# Altium schematic sheet Boom Control.SchDoc("Boom Control")
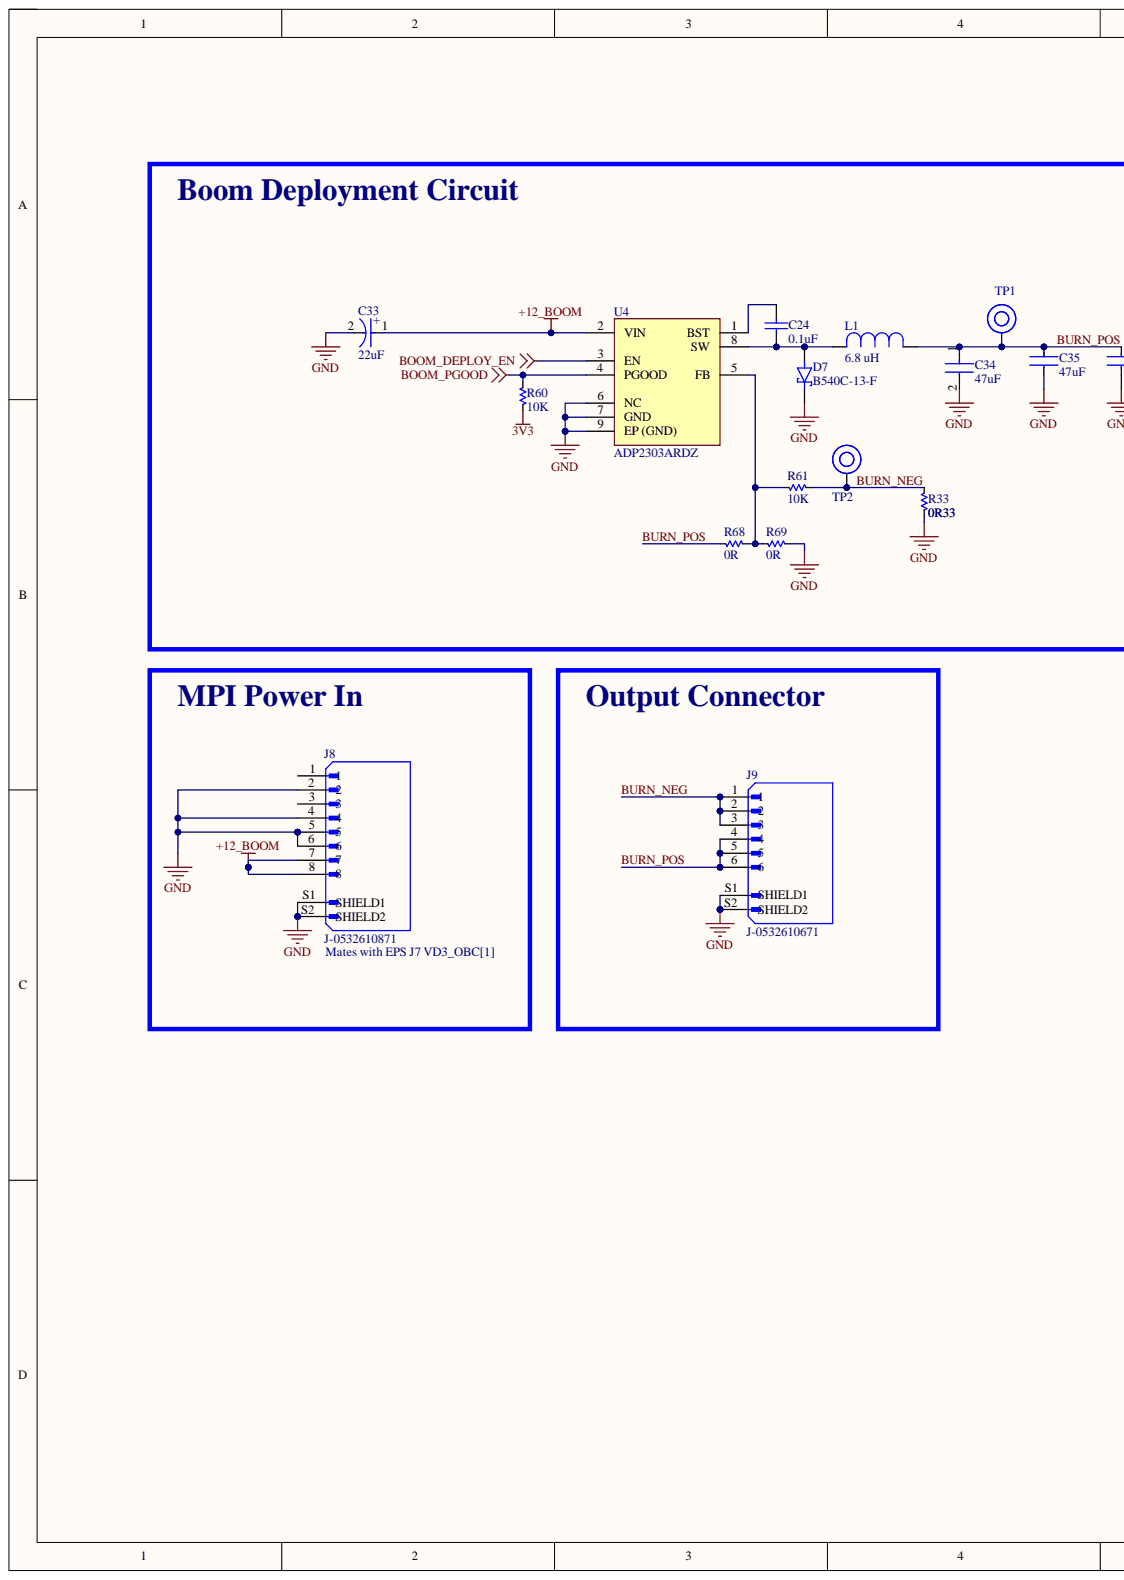
[diagram p0: Boom Control.SchDoc, left]
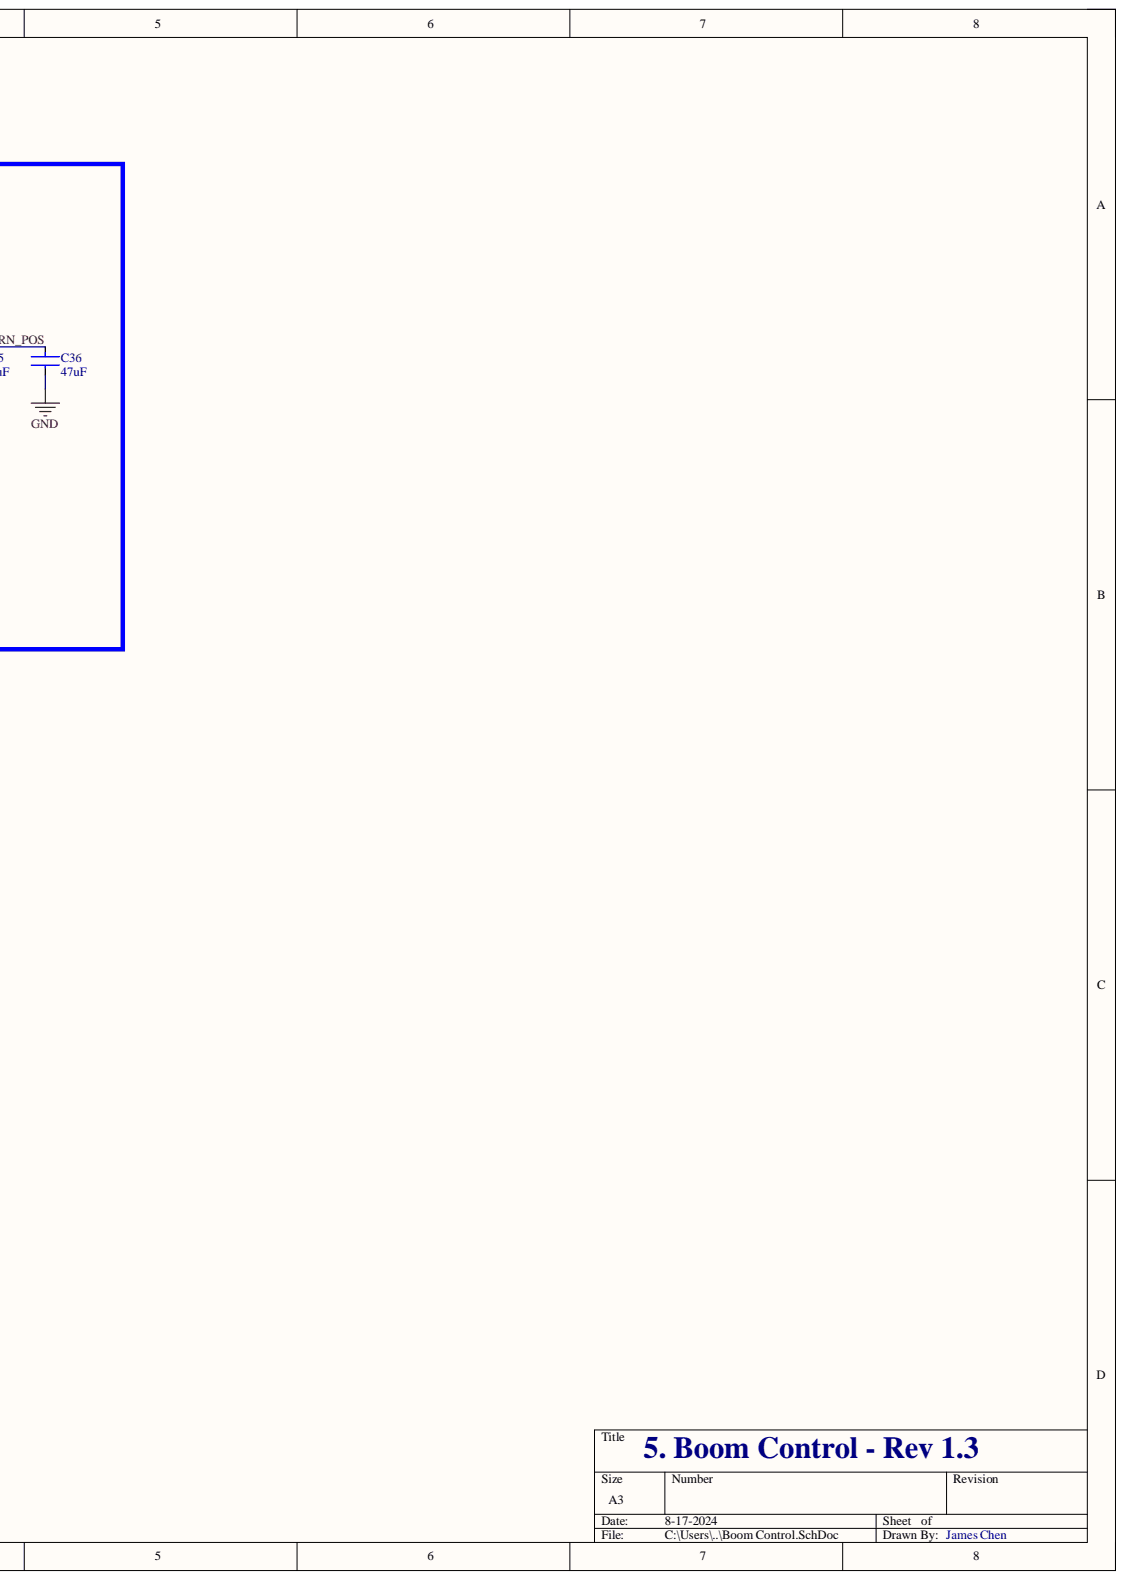
[diagram p1: Boom Control.SchDoc, right]

## Parts
C24  0.1uF  [0603]
C33  22uF  [CAP TANT 1206 (A) TCJ]
C34  47uF  [FP-GRM32E-0_3-0_2-MFG]
C35  47uF  [FP-GRM32E-0_3-0_2-MFG]
C36  47uF  [FP-GRM32E-0_3-0_2-MFG]
D7  B540C-13-F  [D-B540C-13-F]
J8  J-0532610871  [J-532610871]
J9  J-0532610671  [J-0532610671]
L1  6.8 UH  [L-IHLP4040DZER6R8M01]
R33  0R33  [2512]
R60  10K  [0603]
R61  10K  [0603]
R68  0R  [0603]
R69  0R  [0603]
TP1  TP  [TP]  (no BOM)
TP2  TP  [TP]  (no BOM)
U4  ADP2303ARDZ  [U-ADP2303ARDZ]

## Nets
3V3: R60.1
+12_BOOM: C33.1 J8.7 J8.8 U4.2(VIN)
BOOM_DEPLOY_EN: U4.3(EN)
BOOM_PGOOD: R60.2 U4.4(PGOOD)
GND: C33.2 C34.2 C35.2 C36.2 D7.C J8.2 J8.4 J8.5 J8.6 J8.S1(SHIELD1) J8.S2(SHIELD2) J9.S1(SHIELD1) J9.S2(SHIELD2) R33.1 R69.2 U4.6(NC) U4.7(GND) U4.9(EP_(GND))
BURN_NEG: J9.1 J9.2 J9.3 R33.2 R61.2 TP2.1
BURN_POS: C34.1 C35.1 C36.1 J9.4 J9.5 J9.6 L1.1 R68.1 TP1.1
NetC24_1: C24.1 D7.A L1.2 U4.8(SW)
NetC24_2: C24.2 U4.1(BST)
NetR61_1: R61.1 R68.2 R69.1 U4.5(FB)

Not connected: J8 1 3

## Library data of the parts
C24 = CC-100nF-25V-X7R-0603: 25V 100nF X7R ±10% 0603 Multilayer Ceramic Capacitors MLCC - SMD/SMT ROHS; Manufacturer: Murata Electronics; Manufacturer Part #: GCJ188R71E104KA12D; Max Exposed Voltage: 6; Rated Voltage: 25; Component Kind: Standard; ComponentLink1description: Datasheet; ComponentLink1URL: https://ds.yuden.co.jp/TYCOMPAS/ut/detail?pn=TMK105BJ104KV-F%20%20&u=M; ComponentLink2description: Manufacturer Website; ComponentLink2URL: https://www.yuden.co.jp/or/; Distributor: LCSC; Distributor Part #: C354391; Highest Rated Operating Temperature: 125; Lowest Rated Operating Temperature: -55; Part Description: 25V 100nF X7R ±10% 0603 Multilayer Ceramic Capacitors MLCC - SMD/SMT ROHS; Value: 0.1uF; Library Name: OBC Development Board.SchLib
C33 = CMP-04424-000242-1: 22uF 25V  ±10% 1.4Ω 2312  SMD Tantalum Capacitor, TAJC226K025RNJ; Dissipation Factor: 6%; ESR (Equivalent Series Resistance): 1.4R; Leakage Current: 5.5uA; Polarity: Polar; Capacitance: 22uF; Case Code (Imperial): 2312; Case Code (Metric): 6032; Case/Package: 2412; Component Kind: Standard; Construction: Flat; Depth: 3.2mm; Dielectric Material: Tantalum; Features: General Purpose; Height: 2.6mm; Height - Seated (Max): 0.11inch; Lead Free: Lead Free; Length: 6mm; Library Name: Altium Content Vault; Max Operating Temperature: 125°C; Min Operating Temperature: -55°C; Mount: Surface Mount; Number of Pins: 2; Package Quantity: 1; Packaging: Tape and Reel; Radiation Hardening: No; REACH SVHC: No SVHC; RoHS Compliant: Yes; Series: TAJ; Termination: SMD/SMT; Tolerance: 10%; Voltage: 25V; Voltage Rating: 25V; Voltage Rating (DC): 25V; Width: 3.2mm
C34 = CMP-2000-06360-2: CAP CER 47UF 6.3V X5R 1210; Dielectric Material: Ceramic; Insulation Resistance: 10.6MR; Tolerance: 10%; Capacitance: 47uF; Case Code (Imperial): 1210; Case Code (Metric): 3225; Case/Package: 1210; Component Kind: Standard; Construction: Flat; Depth: 2.5mm; Dielectric: X5R; Height: 2.5mm; Lead Free: Lead Free; Length: 3.2mm; Library Name: Altium Content Vault; Max Operating Temperature: 85°C; Min Operating Temperature: -55°C; Mount: Surface Mount; Package Quantity: 1; Packaging: Tape and Reel; Radiation Hardening: No; REACH SVHC: No SVHC; RoHS Compliant: Yes; Series: GRM; Termination: SMD/SMT; Thickness: 0.106inch; Voltage: 6.3V; Voltage Rating: 6.3V; Voltage Rating (DC): 6.3V; Weight: 0.000564oz; Width: 2.5mm
C35 C36 = CC-GRM32ER60J476ME20L: CAP CER 47UF 6.3V X5R 1210; Dielectric Material: Ceramic; Insulation Resistance: 10.6MR; Tolerance: 10%; Capacitance: 47uF; Case Code (Imperial): 1210; Case Code (Metric): 3225; Case/Package: 1210; Component Kind: Standard; Construction: Flat; Depth: 2.5mm; Dielectric: X5R; Height: 2.5mm; Lead Free: Lead Free; Length: 3.2mm; Max Operating Temperature: 85°C; Min Operating Temperature: -55°C; Mount: Surface Mount; Package Quantity: 1; Packaging: Tape and Reel; Radiation Hardening: No; REACH SVHC: No SVHC; RoHS Compliant: Yes; Series: GRM; Termination: SMD/SMT; Thickness: 0.106inch; Voltage: 6.3V; Voltage Rating: 6.3V; Voltage Rating (DC): 6.3V; Weight: 0.000564oz; Width: 2.5mm; Library Name: OBC Development Board.SchLib
D7 = D-B540C-13-F: DIODE SCHOTTKY 40V 5A SMC; Manufacturer: Diodes Incorporated; Component Kind: Standard; Distributor: Digikey; Distributor Part #: B540C-FDICT-ND; Manufacturer Part #: B540C-13-F; Library Name: Burn Wire Dev Board.SchLib
J8 = J-0532610871: CONN HEADER SMD R/A 8POS 1.25MM; Manfacturer: Molex; Component Kind: Standard; Distributor: Digi-Key; Distributor Part #: WM7626CT-ND; Manfacturer Part #: 0532610871; Library Name: OBC Development Board.SchLib
J9 = J-0532610671: CONN HEADER SMD R/A 6POS 1.25MM; Manfacturer: Molex; Component Kind: Standard; Distributor: Digi-Key; Distributor Part #: WM7624CT-ND; Manfacturer Part #: 0532610671; Library Name: Burn Wire Dev Board.SchLib
L1 = L-IHLP4040DZER6R8M01: FIXED IND 6.8UH 8A 23.3 MOHM SMD; Manufacturer: Vishay Dale; Component Kind: Standard; Distributor: Digikey; Distributor Part #: 541-1303-1-ND; Manufacturer Part #: IHLP4040DZER6R8M01; Value: 6.8 uH; Library Name: Burn Wire Dev Board.SchLib
R33 = RT-0R33-F-4W-2512: 330 mOhms ±1% 4W Chip Resistor 2512 (6432 Metric) Automotive AEC-Q200, Current Sense, Moisture Resistant, Pulse Withstanding Metal Foil; Manufacturer: Isabellenhuette USA; Manufacturer Part #: VMS-R330-1.0-U; Component Kind: Standard; Distributer: Digikey; Distributer #: 4423-VMS-R330-1.0-UCT-ND; Part Description: 330 mOhms ±1% 4W Chip Resistor 2512 (6432 Metric) Automotive AEC-Q200, Current Sense, Moisture Resistant, Pulse Withstanding Metal Foil; Supplier 1: Digikey; Supplier Part Number 1: 4423-VMS-R330-1.0-UCT-ND; Value: 0R33; Library Name: OBC Development Board.SchLib
R60 R61 = RT-10K0-F-1/16W-0603: RES 10K OHM 1% 1/8W 0603; Manufacturer: Stackpole Electronics Inc; Manufacturer Part #: RNCP0603FTD10K0; Component Kind: Standard; ComponentLink1description: Datasheet; ComponentLink1URL: https://www.vishay.com/docs/20035/dcrcwe3.pdf; ComponentLink2description: Manufacturer Website; ComponentLink2URL: https://www.vishay.com/; Distributor: Digikey; Distributor Part #: RNCP0603FTD10K0CT-ND; Part Description: RES 10K OHM 1% 1/8W 0603; Value: 10K; Library Name: Burn Wire Dev Board.SchLib
R68 R69 = RT-0R00-F-1/10W-0603: 0 Ohms Jumper 0.1W, 1/10W Chip Resistor 0603 (1608 Metric) Automotive AEC-Q200 Thick Film; Manufacturer: Stackpole Electronics Inc; Manufacturer Part #: RMCF0603ZT0R00; Component Kind: Standard; ComponentLink1description: Datasheet; ComponentLink1URL: https://industrial.panasonic.com/ww/products/resistors/chip-resistors/general-purpose-chip-resistors/models/ERJ2GE0R00X; ComponentLink2description: Manufacturer Website; ComponentLink2URL: https://industrial.panasonic.com/ww/products/resistors/chip-resistors/general-purpose-chip-resistors/models/ERJ2GE0R00X; Distributor: Digikey; Distributor Part #: RMCF0603ZT0R00CT-ND; Part Description: 0 Ohms Jumper 0.1W, 1/10W Chip Resistor 0603 (1608 Metric) Automotive AEC-Q200 Thick Film; Value: 0R; Library Name: OBC Development Board.SchLib
TP1 TP2 = TP: Test Point; ComponentLink1description: Datasheet; ComponentLink1URL: https://industrial.panasonic.com/ww/products/resistors/chip-resistors/general-purpose-chip-resistors/models/ERJ2GE0R00X; ComponentLink2description: Manufacturer Website; ComponentLink2URL: https://industrial.panasonic.com/ww/products/resistors/chip-resistors/general-purpose-chip-resistors/models/ERJ2GE0R00X; Library Name: PowerManagmentBoard_V4.0.SchLib
U4 = U-ADP2303ARDZ: IC REG BUCK ADJUSTABLE 3A 8SOIC; Manufacturer: Analog Devices Inc.; Component Kind: Standard; Distributor: Digikey; Distributor Part #: 505-ADP2303ARDZ-ND; Manufacturer Part #: ADP2303ARDZ; Library Name: Burn Wire Dev Board.SchLib
